# Altium schematic sheet RFM95.SchDoc(RFM95)
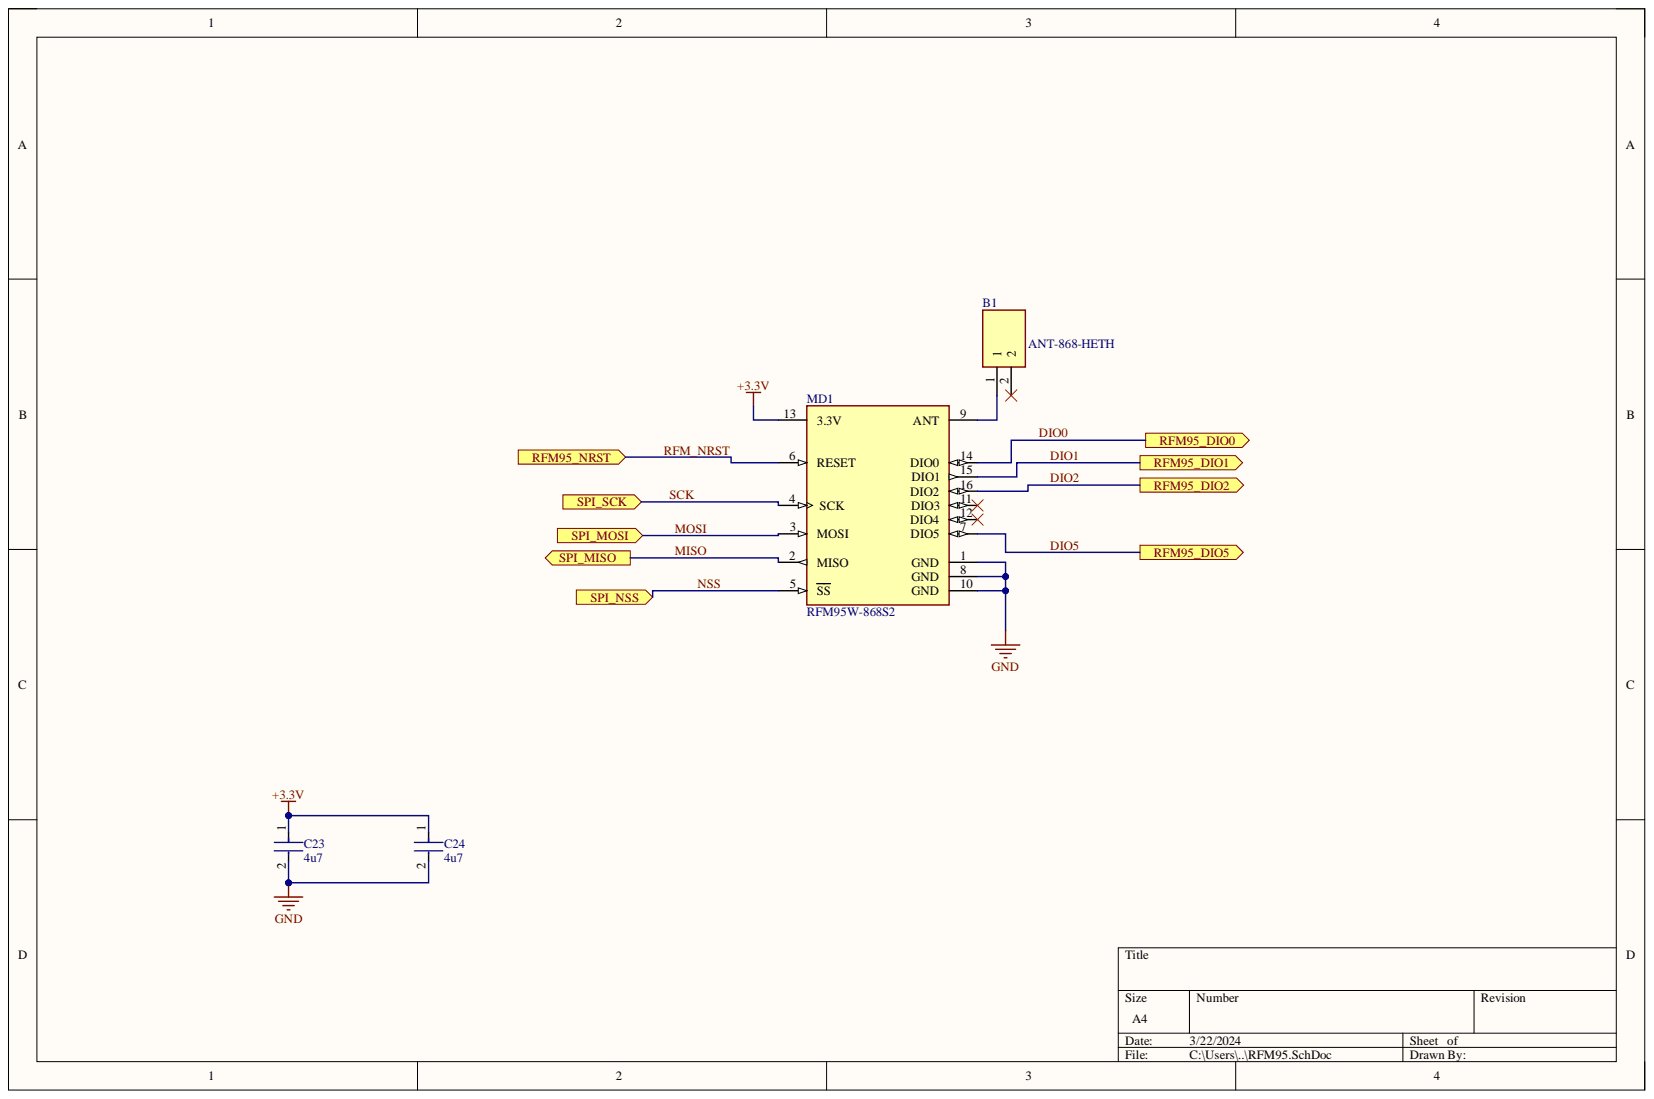
[diagram p0: RFM95.SchDoc]

## Parts
B1  ANT-868-HETH  [ANT-868-HETH-Footprint-1]
C23  4u7  [FP-GRM21B-0_15-MFG]
C24  4u7  [FP-GRM21B-0_15-MFG]
MD1  RFM95W-868S2  [FP-RFM95W-868S2-MFG]

## Ports
RFM95_DIO0, RFM95_DIO1, RFM95_DIO2, RFM95_DIO5, RFM95_NRST, SPI_MISO, SPI_MOSI, SPI_NSS, SPI_SCK

## Nets
+3.3V: C23.1 C24.1 MD1.13(3.3V)
GND: C23.2 C24.2 MD1.1(GND) MD1.8(GND) MD1.10(GND)
DIO0: MD1.14(DIO0) ; port RFM95_DIO0
DIO1: MD1.15(DIO1) ; port RFM95_DIO1
DIO2: MD1.16(DIO2) ; port RFM95_DIO2
DIO5: MD1.7(DIO5) ; port RFM95_DIO5
MISO: MD1.2(MISO) ; port SPI_MISO
MOSI: MD1.3(MOSI) ; port SPI_MOSI
NSS: MD1.5(SS) ; port SPI_NSS
RFM_NRST: MD1.6(RESET) ; port RFM95_NRST
SCK: MD1.4(SCK) ; port SPI_SCK
NetB1_1: B1.1 MD1.9(ANT)

Not connected: B1 2; MD1 11(DIO3) 12(DIO4)

## Library data of the parts
B1 = CMP-00030-00001-2: RF/Microwave Antenna; Component Kind: Standard; Library Name: tutorial_PCB
C23 C24 = CMP-06035-005997-1: Chip Multilayer Ceramic Capacitors for General Purpose, 0805, 4.7uF, X7R, 15%, 10%, 10V; Capacitance: 4.7uF; Case/Package: 0805; Component Kind: Standard; Dielectric: X7R; Length: 2mm; Library Name: Altium Content Vault; Thickness: 1.25mm; Tolerance: 10%; Voltage Rating (DC): 10V; Width: 1.25mm
MD1 = CMP-204316-000001-1: RX TXRX MODULE ISM < 1GHZ SMD; Current - Receiving: 12.1mA; Current - Transmitting: 120mA; Data Rate: 300Kbps; Frequency: 868MHz; Interface: SPI; Sensitivity: -123dBm; Case/Package: SMD/SMT; Component Kind: Standard; Library Name: Altium Content Vault; Max Operating Temperature: 70°C; Min Operating Temperature: -20°C; Mount: Surface Mount; Packaging: Bulk
